# Altium schematic sheet 07_LED&KEY.SchDoc
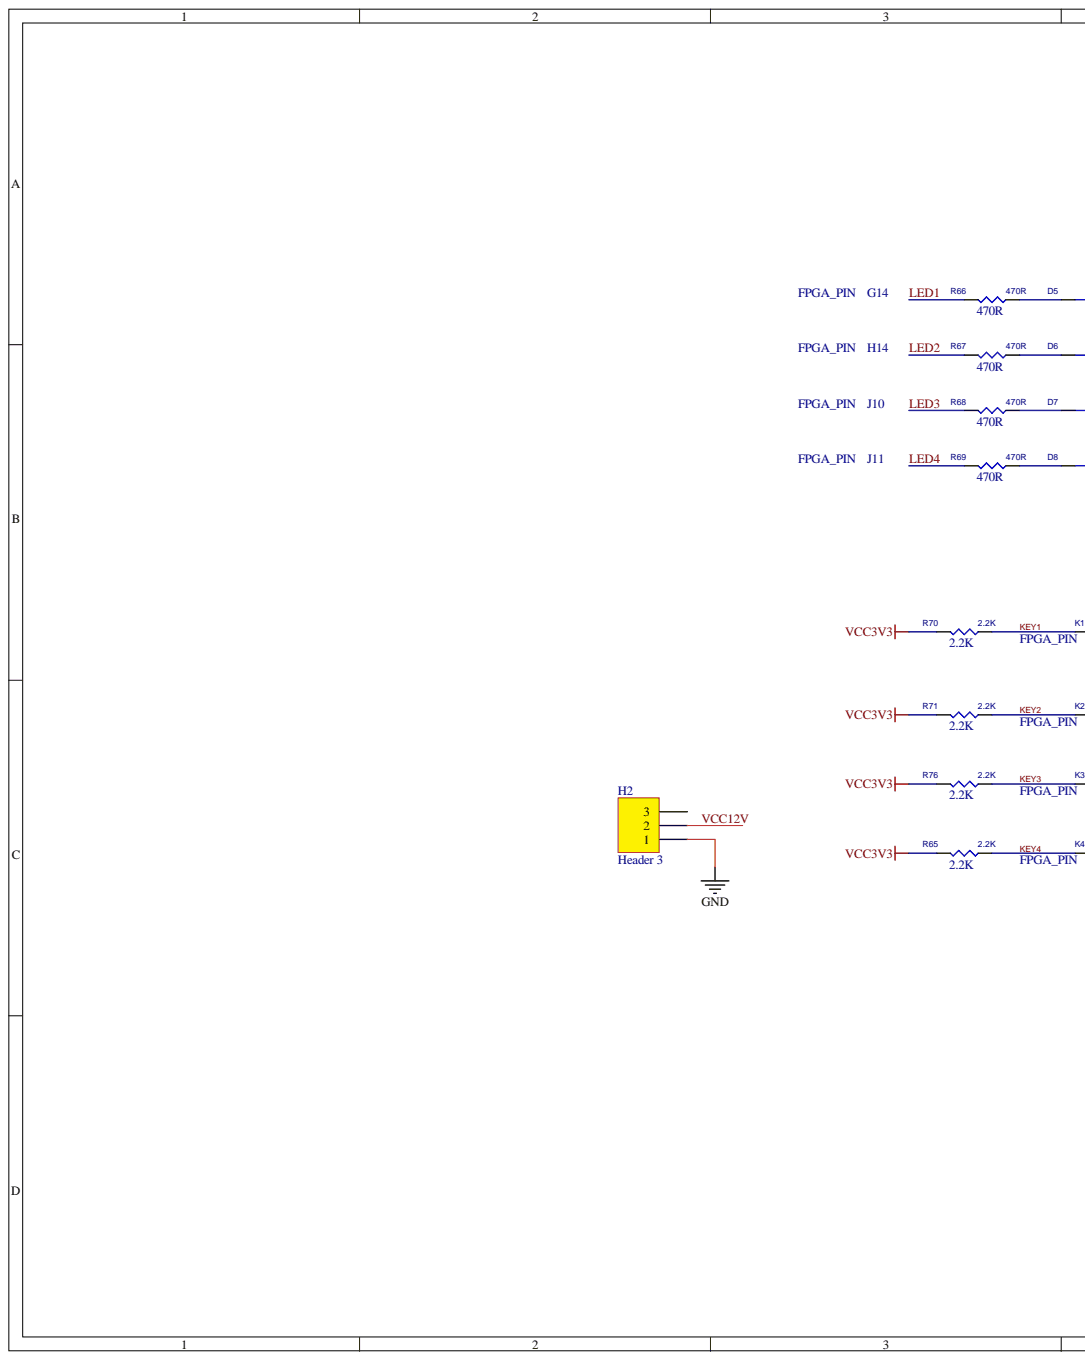
[diagram p0: 07_LED&KEY.SchDoc, left]
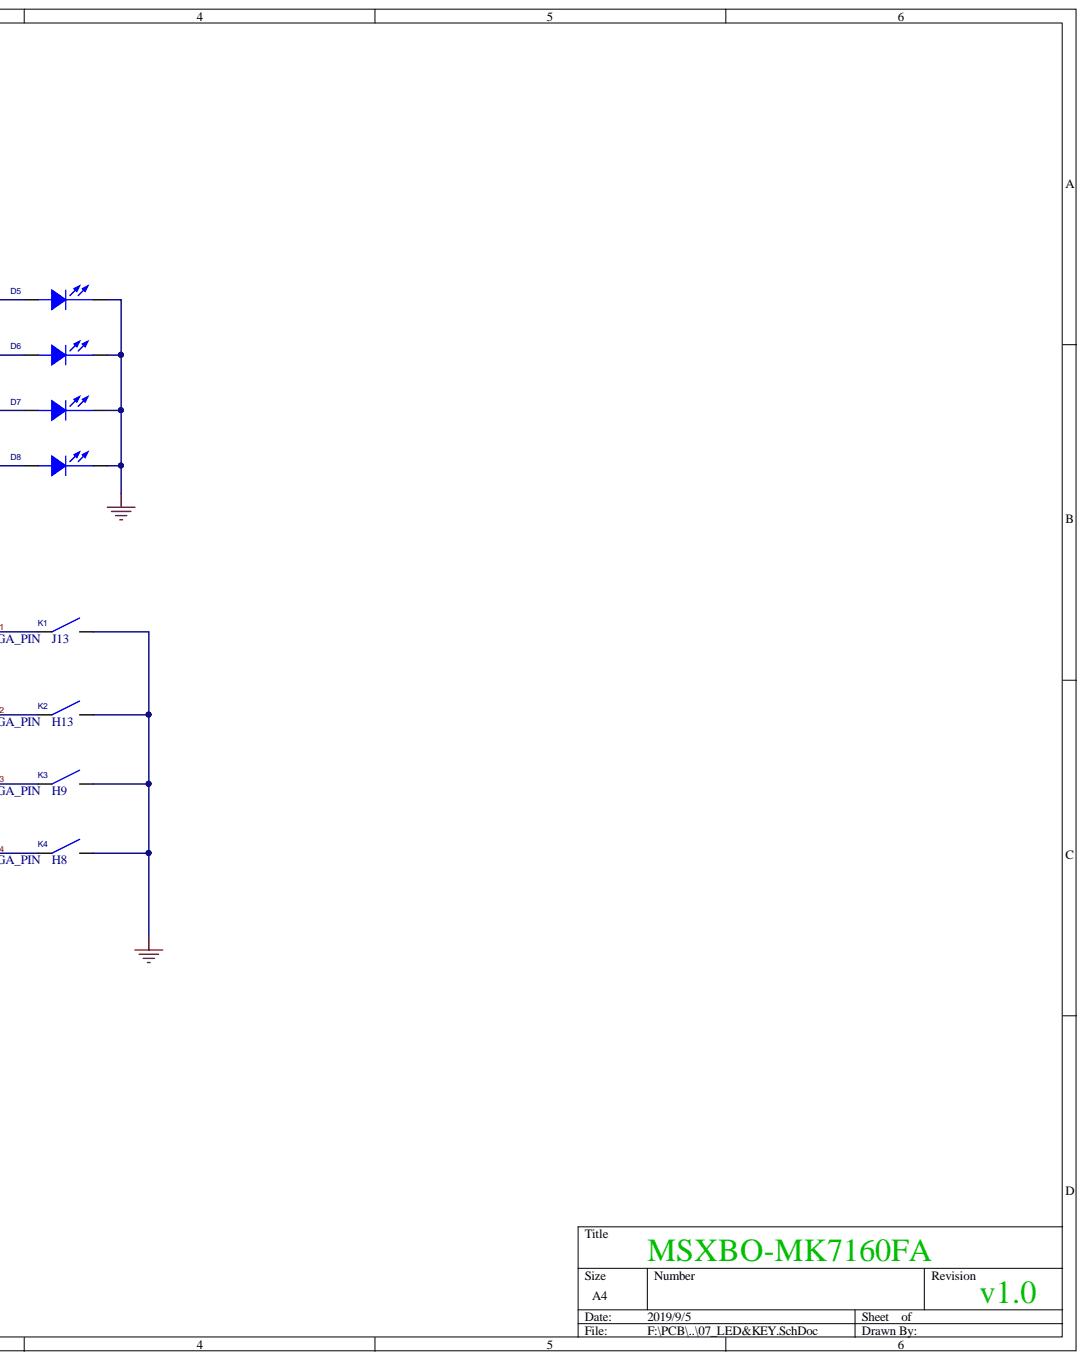
[diagram p1: 07_LED&KEY.SchDoc, right]

## Parts
D5  RED  [D0603]  (value not drawn)
D6  RED  [D0603]  (value not drawn)
D7  RED  [D0603]  (value not drawn)
D8  RED  [D0603]  (value not drawn)
H2  Header 3  [HDR1X3]
K1  KEY1  [TS_3]
K2  KEY1  [TS_3]  (value not drawn)
K3  KEY1  [TS_3]  (value not drawn)
K4  KEY1  [TS_3]  (value not drawn)
R65  2.2K  [R0603]
R66  470R  [R0603]
R67  470R  [R0603]
R68  470R  [R0603]
R69  470R  [R0603]
R70  2.2K  [R0603]
R71  2.2K  [R0603]
R76  2.2K  [R0603]

## Nets
GND: D5.2 D6.2 D7.2 D8.2 H2.1 K1.2 K2.2 K3.2 K4.2
VCC3V3: R65.1 R70.1 R71.1 R76.1
KEY1: K1.1 R70.2
KEY2: K2.1 R71.2
KEY3: K3.1 R76.2
KEY4: K4.1 R65.2
LED1: R66.1
LED2: R67.1
LED3: R68.1
LED4: R69.1
VCC12V: H2.2
NetD5_1: D5.1 R66.2
NetD6_1: D6.1 R67.2
NetD7_1: D7.1 R68.2
NetD8_1: D8.1 R69.2
FPGA_PIN:

Not connected: H2 3

## Library data of the parts
D5-D8 = LED1: Component Kind: Standard
H2 = Header 3: Header, 3-Pin; Component Kind: Standard; LatestRevisionDate: 17-Jul-2002; LatestRevisionNote: Re-released for DXP Platform.; Signal Integrity: Connector; Library Name: jinrylib.IntLib; Publisher: Altium Limited
R65-R71 R76 = RES1: DIST: FLAT; MAX_TEMP: RTMAX; POWER: RMAX; PSpiceTemplate: R^@REFDES %1 %2 ?TOLERANCE|R^@REFDES| @VALUE TC=@TC1,@TC2 ?TOLERANCE|\n.model R^@REFDES RES R=1 DEV=@TOLERANCE% TC1=@TC1 TC2=@TC2|; SLOPE: RSMAX; TC1: 0; VOLTAGE: RVMAX; Component Kind: Standard; Simulation: RES1; TC2: 0
  R65 R70 R71 R76: Value: 2.2K
  R66-R69: Value: 470R
K1-K4 = KEY1: Component Kind: Standard
